# Altium schematic sheet Arduino Nano.SchDoc
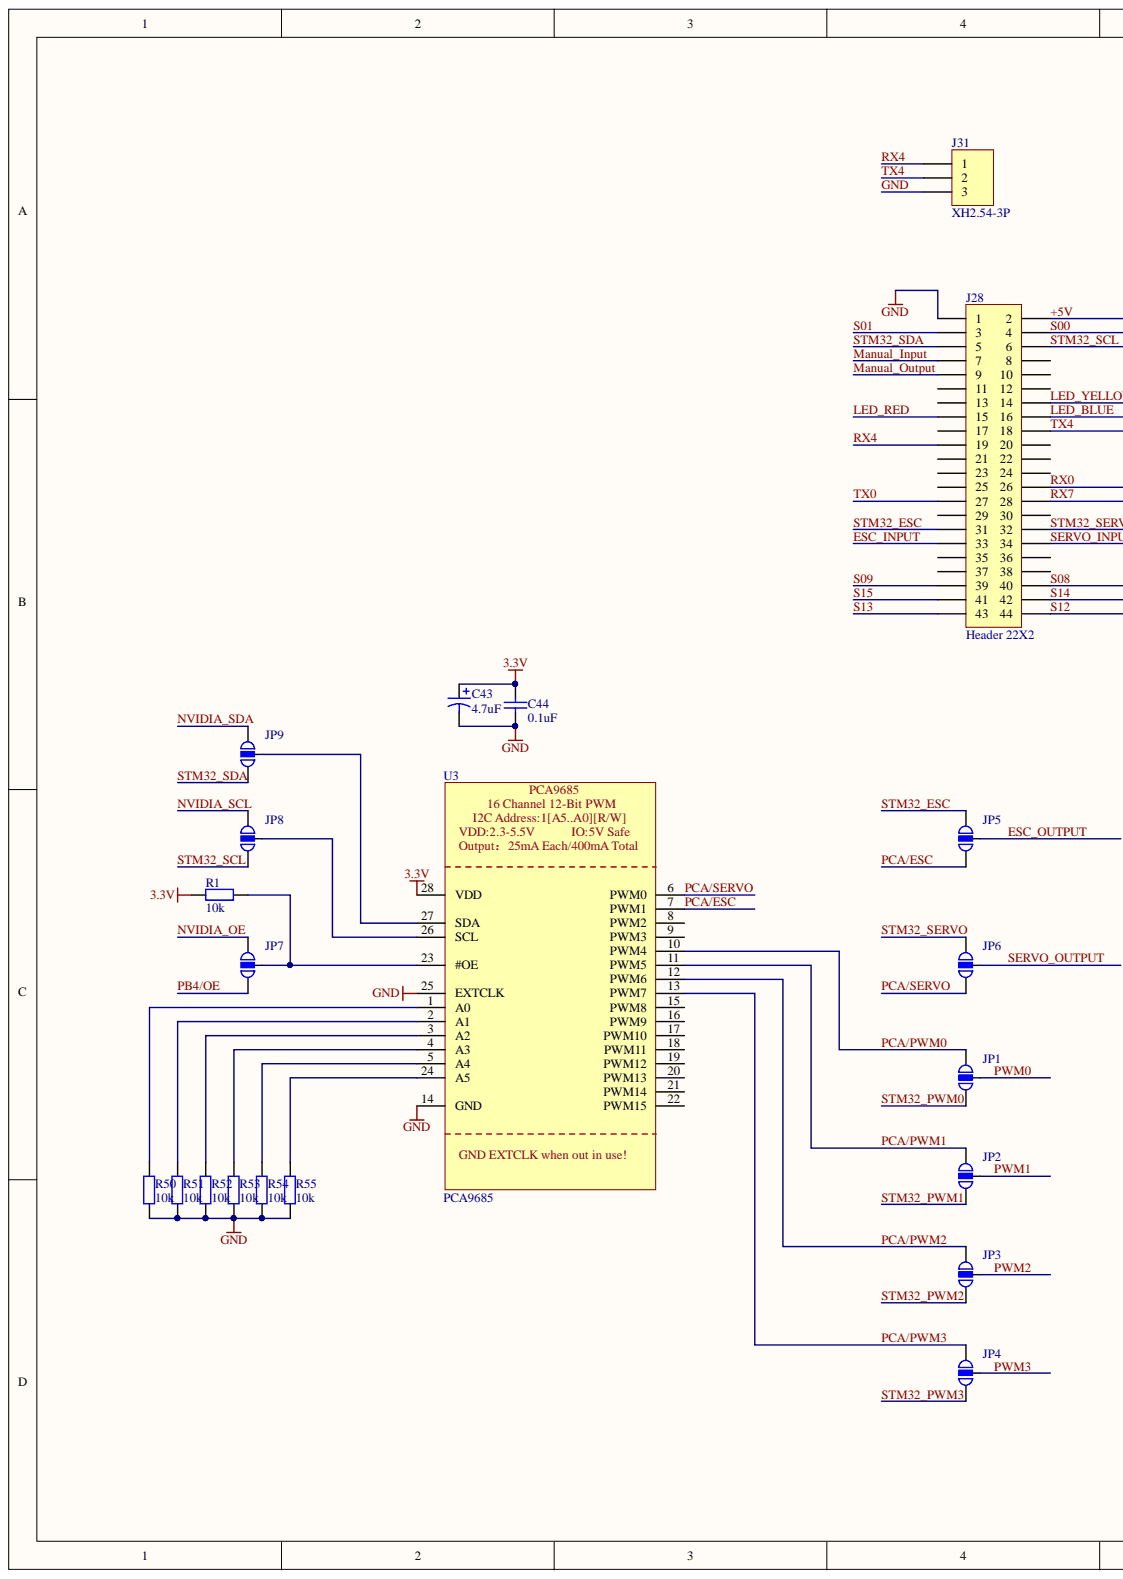
[diagram p0: Arduino Nano.SchDoc, left]
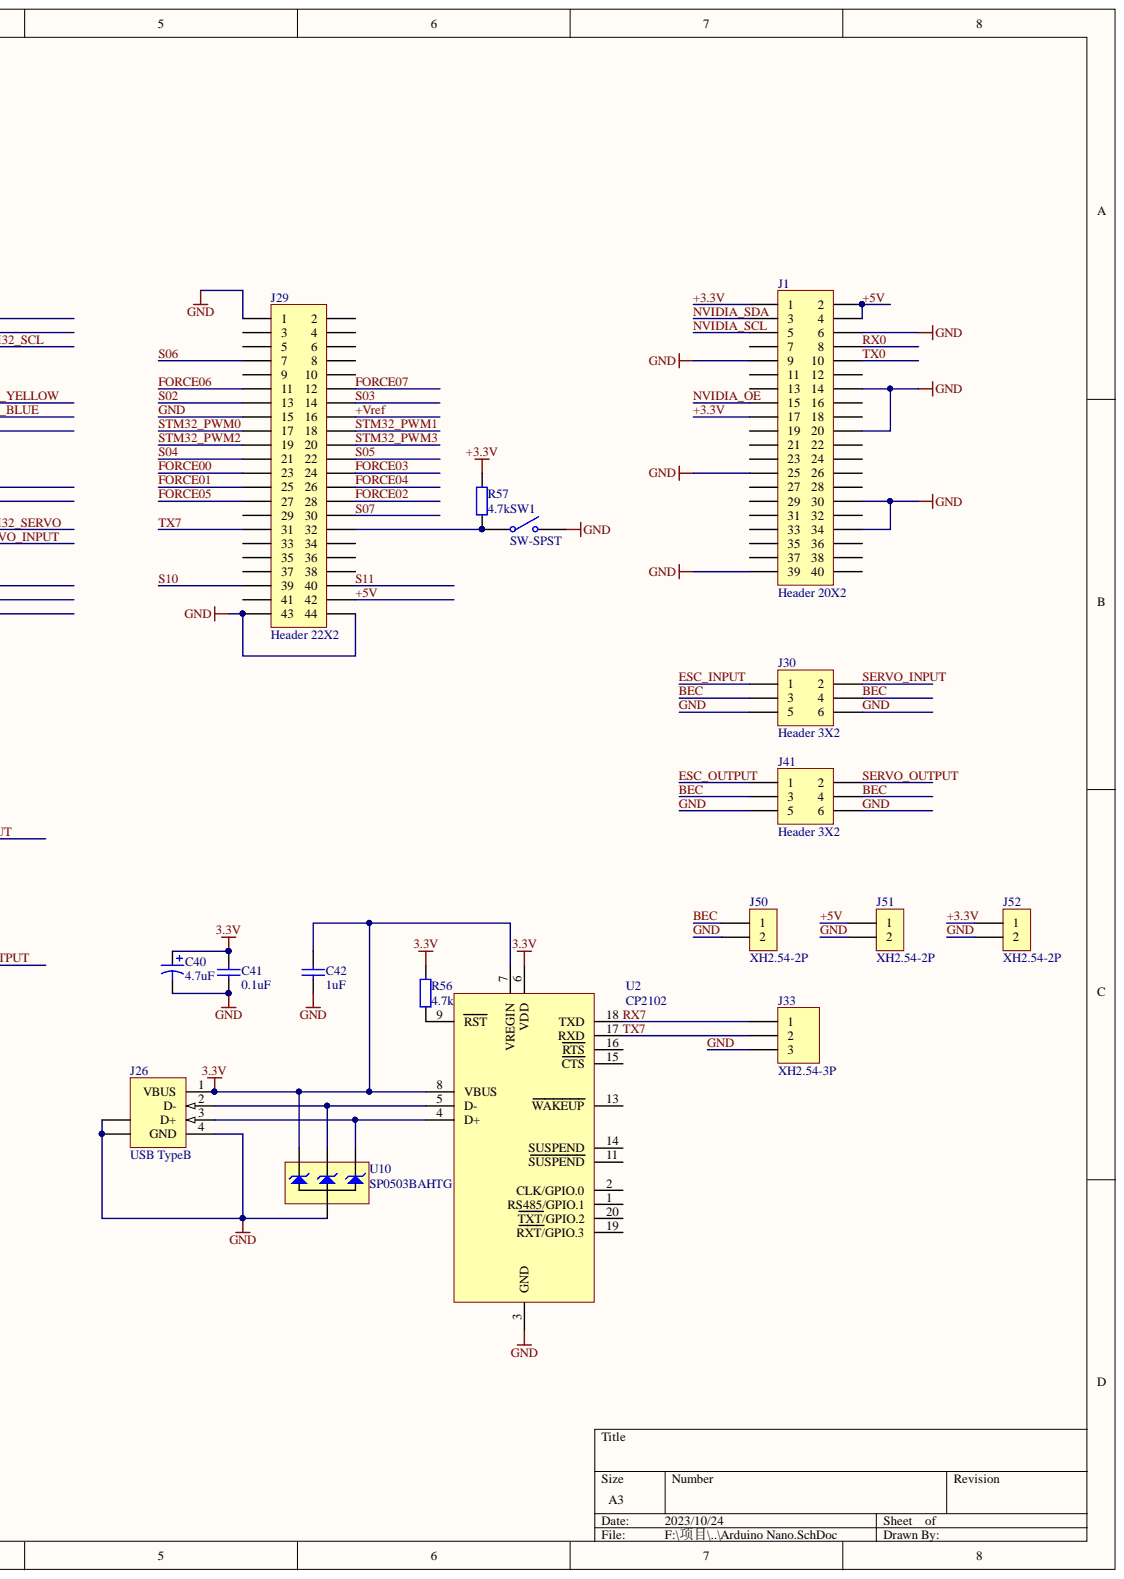
[diagram p1: Arduino Nano.SchDoc, right]

## Parts
C40  value 4.7uF  [SMD-EC-F5]  (hidden comment: CD-50V-4.7uF-F5(SMD))
C41  value 0.1uF  [CC0805]  (hidden comment: 0805-50V-0.1uF)
C42  value 1uF  [CC0805]  (hidden comment: 0805-50V-1uF)
C43  value 4.7uF  [SMD-EC-F5]  (hidden comment: CD-50V-4.7uF-F5(SMD))
C44  value 0.1uF  [CC0805]  (hidden comment: 0805-50V-0.1uF)
J1  Header 20X2  [HDR2X20]
J26  USB TypeB  [USB TypeA 平插]
J28  Header 22X2  [HDR2X22]
J29  Header 22X2  [HDR2X22]
J30  Header 3X2  [HDR2X3]
J31  XH2.54-3P  [XH2.54-3P]
J33  XH2.54-3P  [XH2.54-3P]
J41  Header 3X2  [HDR2X3]
J50  XH2.54-2P  [XH2.54-2P]
J51  XH2.54-2P  [XH2.54-2P]
J52  XH2.54-2P  [XH2.54-2P]
JP1  Jumper  [PIN2]  (value not drawn)
JP2  Jumper  [PIN2]  (value not drawn)
JP3  Jumper  [PIN2]  (value not drawn)
JP4  Jumper  [PIN2]  (value not drawn)
JP5  Jumper  [PIN2]  (value not drawn)
JP6  Jumper  [PIN2]  (value not drawn)
JP7  Jumper  [PIN2]  (value not drawn)
JP8  Jumper  [PIN2]  (value not drawn)
JP9  Jumper  [PIN2]  (value not drawn)
R1  value 10k  [0805]  (hidden comment: 0805-10kΩ±1％)
R50  value 10k  [0805]  (hidden comment: 0805-10kΩ±1％)
R51  value 10k  [0805]  (hidden comment: 0805-10kΩ±1％)
R52  value 10k  [0805]  (hidden comment: 0805-10kΩ±1％)
R53  value 10k  [0805]  (hidden comment: 0805-10kΩ±1％)
R54  value 10k  [0805]  (hidden comment: 0805-10kΩ±1％)
R55  value 10k  [0805]  (hidden comment: 0805-10kΩ±1％)
R56  value 4.7k  [0805]  (hidden comment: 0805-4.7kΩ±1％)
R57  value 4.7k  [0805]  (hidden comment: 0805-4.7kΩ±1％)
SW1  SW-SPST  [SPST-2]
U2  CP2102
U3  PCA9685
U10  SP0503BAHTG  [SOT223]

## Nets
3.3V: C40.1 C41.2 C42.2 C43.1 C44.2 J26.1(VBUS) R1.1 R56.1 U2.6(VDD) U2.7(VREGIN) U2.8(VBUS) U3.28(VDD) U10.2
+3.3V: J1.1 J1.17 J52.1 R57.1
+5V: J1.2 J1.4 J28.2 J29.42 J51.1
+VREF: J29.16
BEC: J30.3 J30.4 J41.3 J41.4 J50.1
ESC_INPUT: J28.33 J30.1
ESC_OUTPUT: J41.1 JP5.2
FORCE00: J29.23
FORCE01: J29.25
FORCE02: J29.28
FORCE03: J29.24
FORCE04: J29.26
FORCE05: J29.27
FORCE06: J29.11
FORCE07: J29.12
GND: C40.2 C41.1 C42.1 C43.2 C44.1 J1.6 J1.9 J1.14 J1.20 J1.25 J1.30 J1.34 J1.39 J26.4(GND) J26.5 J26.6 J28.1 J29.1 J29.15 J29.43 J29.44 J30.5 J30.6 J31.3 J33.3 J41.5 J41.6 J50.2 J51.2 J52.2 R50.1 R51.1 R52.1 R53.1 R54.1 R55.1 SW1.2 U2.3(GND) U3.14(GND) U3.25(EXTCLK) U10.1
LED_BLUE: J28.16
LED_RED: J28.15
LED_YELLOW: J28.14
MANUAL_INPUT: J28.7
MANUAL_OUTPUT: J28.9
NVIDIA_OE: J1.15 JP7.1
NVIDIA_SCL: J1.5 JP8.1
NVIDIA_SDA: J1.3 JP9.1
PB4/OE: JP7.3
PCA/ESC: JP5.3 U3.7(PWM1)
PCA/PWM0: JP1.1 U3.10(PWM4)
PCA/PWM1: JP2.1 U3.11(PWM5)
PCA/PWM2: JP3.1 U3.12(PWM6)
PCA/PWM3: JP4.1 U3.13(PWM7)
PCA/SERVO: JP6.3 U3.6(PWM0)
PWM0: JP1.2
PWM1: JP2.2
PWM2: JP3.2
PWM3: JP4.2
RX0: J1.8 J28.26
RX4: J28.19 J31.1
RX7: J28.28 J33.1 U2.18(TXD)
S00: J28.4
S01: J28.3
S02: J29.13
S03: J29.14
S04: J29.21
S05: J29.22
S06: J29.7
S07: J29.30
S08: J28.40
S09: J28.39
S10: J29.39
S11: J29.40
S12: J28.44
S13: J28.43
S14: J28.42
S15: J28.41
SERVO_INPUT: J28.34 J30.2
SERVO_OUTPUT: J41.2 JP6.2
STM32_ESC: J28.31 JP5.1
STM32_PWM0: J29.17 JP1.3
STM32_PWM1: J29.18 JP2.3
STM32_PWM2: J29.19 JP3.3
STM32_PWM3: J29.20 JP4.3
STM32_SCL: J28.6 JP8.3
STM32_SDA: J28.5 JP9.3
STM32_SERVO: J28.32 JP6.1
TX0: J1.10 J28.27
TX4: J28.18 J31.2
TX7: J29.31 J33.2 U2.17(RXD)
NetJ26_2: J26.2(D-) U2.5(D-) U10.3
NetJ26_3: J26.3(D+) U2.4(D+) U10.4
NetJ29_32: J29.32 R57.2 SW1.1
NetJP7_2: JP7.2 R1.2 U3.23(#OE)
NetJP8_2: JP8.2 U3.26(SCL)
NetJP9_2: JP9.2 U3.27(SDA)
NetR50_2: R50.2 U3.1(A0)
NetR51_2: R51.2 U3.2(A1)
NetR52_2: R52.2 U3.3(A2)
NetR53_2: R53.2 U3.4(A3)
NetR54_2: R54.2 U3.5(A4)
NetR55_2: R55.2 U3.24(A5)
NetR56_2: R56.2 U2.9(RST)
NetU3_9: U3.9(PWM3)
NetU3_16: U3.16(PWM9)
NetU3_18: U3.18(PWM11)

Not connected: J1 7 11 12 13 16 18 19 21 22 23 24 26 27 28 29 31 32 33 35 36 37 38 40; J28 8 10 11 12 13 17 20 21 22 23 24 25 29 30 35 36 37 38; J29 2 3 4 5 6 8 9 10 29 33 34 35 36 37 38 41; U2 1(RS485/GPIO.1) 2(CLK/GPIO.0) 11(SUSPEND) 13(WAKEUP) 14(SUSPEND) 15(CTS) 16(RTS) 19(RXT/GPIO.3) 20(TXT/GPIO.2); U3 8(PWM2) 15(PWM8) 17(PWM10) 19(PWM12) 20(PWM13) 21(PWM14) 22(PWM15)

## Library data of the parts
C40 C43 = Cap Pol1: 贴片铝电解电容; Component Kind: Standard; Library Name: Miscellaneous Devices.IntLib
C41 C42 C44 = Cap: 贴片电容; Component Kind: Standard; Library Name: Miscellaneous Devices.IntLib
J1 = Header 20X2: Header, 20-Pin, Dual row; Component Kind: Standard; LatestRevisionDate: 17-Jul-2002; LatestRevisionNote: Re-released for DXP Platform.; Library Name: Miscellaneous Connectors.IntLib; Signal Integrity: Connector; Publisher: Altium Limited
J26 = USB TypeB: USB TypeB 方口; Component Kind: Standard; Signal Integrity: USB1.1; Value: USB TypeB; Library Name: USB母座.SchLib
J28 J29 = Header 22X2: Header, 22-Pin, Dual row; Component Kind: Standard; LatestRevisionDate: 17-Jul-2002; LatestRevisionNote: Re-released for DXP Platform.; Library Name: Miscellaneous Connectors.IntLib; Signal Integrity: Connector; Publisher: Altium Limited
J30 J41 = Header 3X2: Header, 3-Pin, Dual row; Component Kind: Standard; LatestRevisionDate: 17-Jul-2002; LatestRevisionNote: Re-released for DXP Platform.; Library Name: Miscellaneous Connectors.IntLib; Signal Integrity: Connector; Publisher: Altium Limited
J31 J33 = Header 3: 插座; Component Kind: Standard; Library Name: Miscellaneous Connectors.IntLib; Signal Integrity: Connector; Value: XH2.54-3P
J50-J52 = CON2: 插座; Component Kind: Standard; Value: XH2.54-2P
JP1-JP9 = Bell: Component Kind: Standard; Description: Jumper; LatestRevisionDate: 17-Jul-2002; LatestRevisionNote: Re-released for DXP Platform.; Library Name: SHIELD_3.SCHLIB; PackageReference: PIN2; Published: 24-Mar-1999; Publisher: Altium Limited
R1 R50-R57 = Res2: 贴片电阻; Component Kind: Standard; Library Name: Miscellaneous Devices.IntLib
SW1 = SW-SPST: Single-Pole, Single-Throw Switch; Component Kind: Standard; LatestRevisionDate: 17-Jul-2002; LatestRevisionNote: Re-released for DXP Platform.; Library Name: Miscellaneous Devices.IntLib; PackageDescription: Switch; 2 Leads; PackageReference: SPST-2; Published: 24-Mar-1999; Publisher: Altium Limited
U10 = SP0503BAHTG: 三端稳压器; Component Kind: Standard; Library Name: PCB_Project.SCHLIB; Value: SP0503BAHTG
U2 = CP2102: Component Kind: Standard; Library Name: Schlib1.SchLib
U3 = PCA9685: Component Kind: Standard; Library Name: Schlib1.SchLib
